# Altium schematic sheet MainBoard.SchDoc
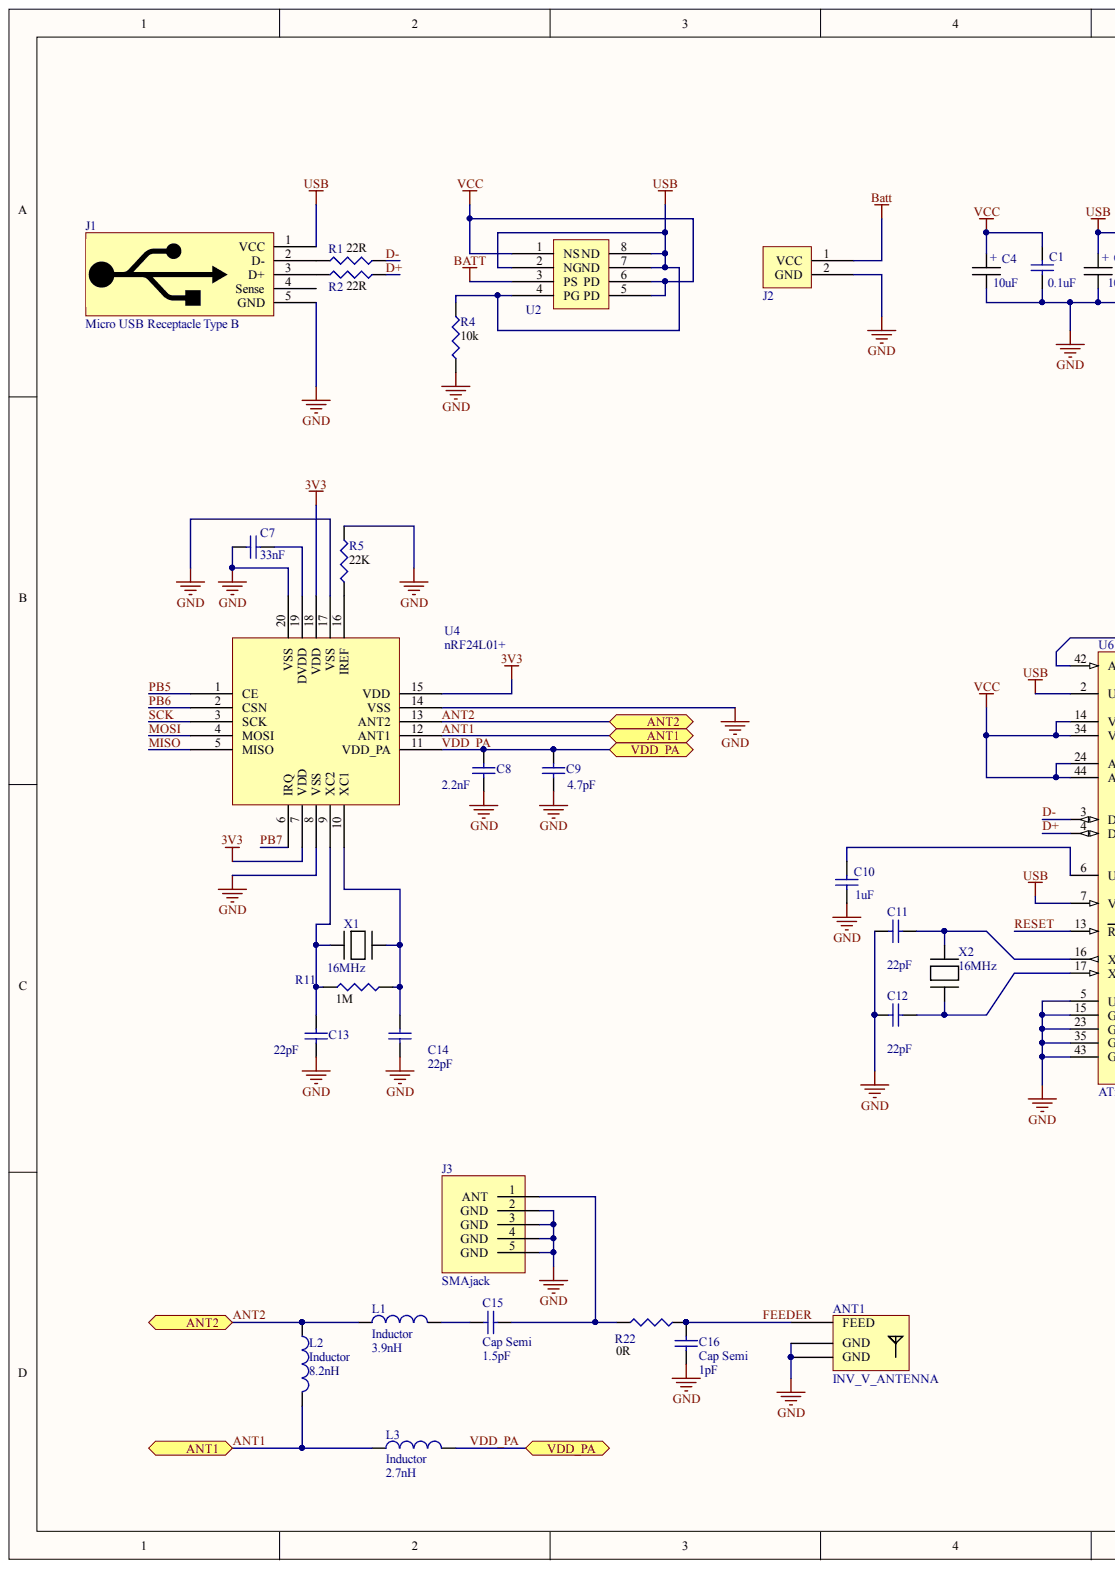
[diagram p0: MainBoard.SchDoc, left]
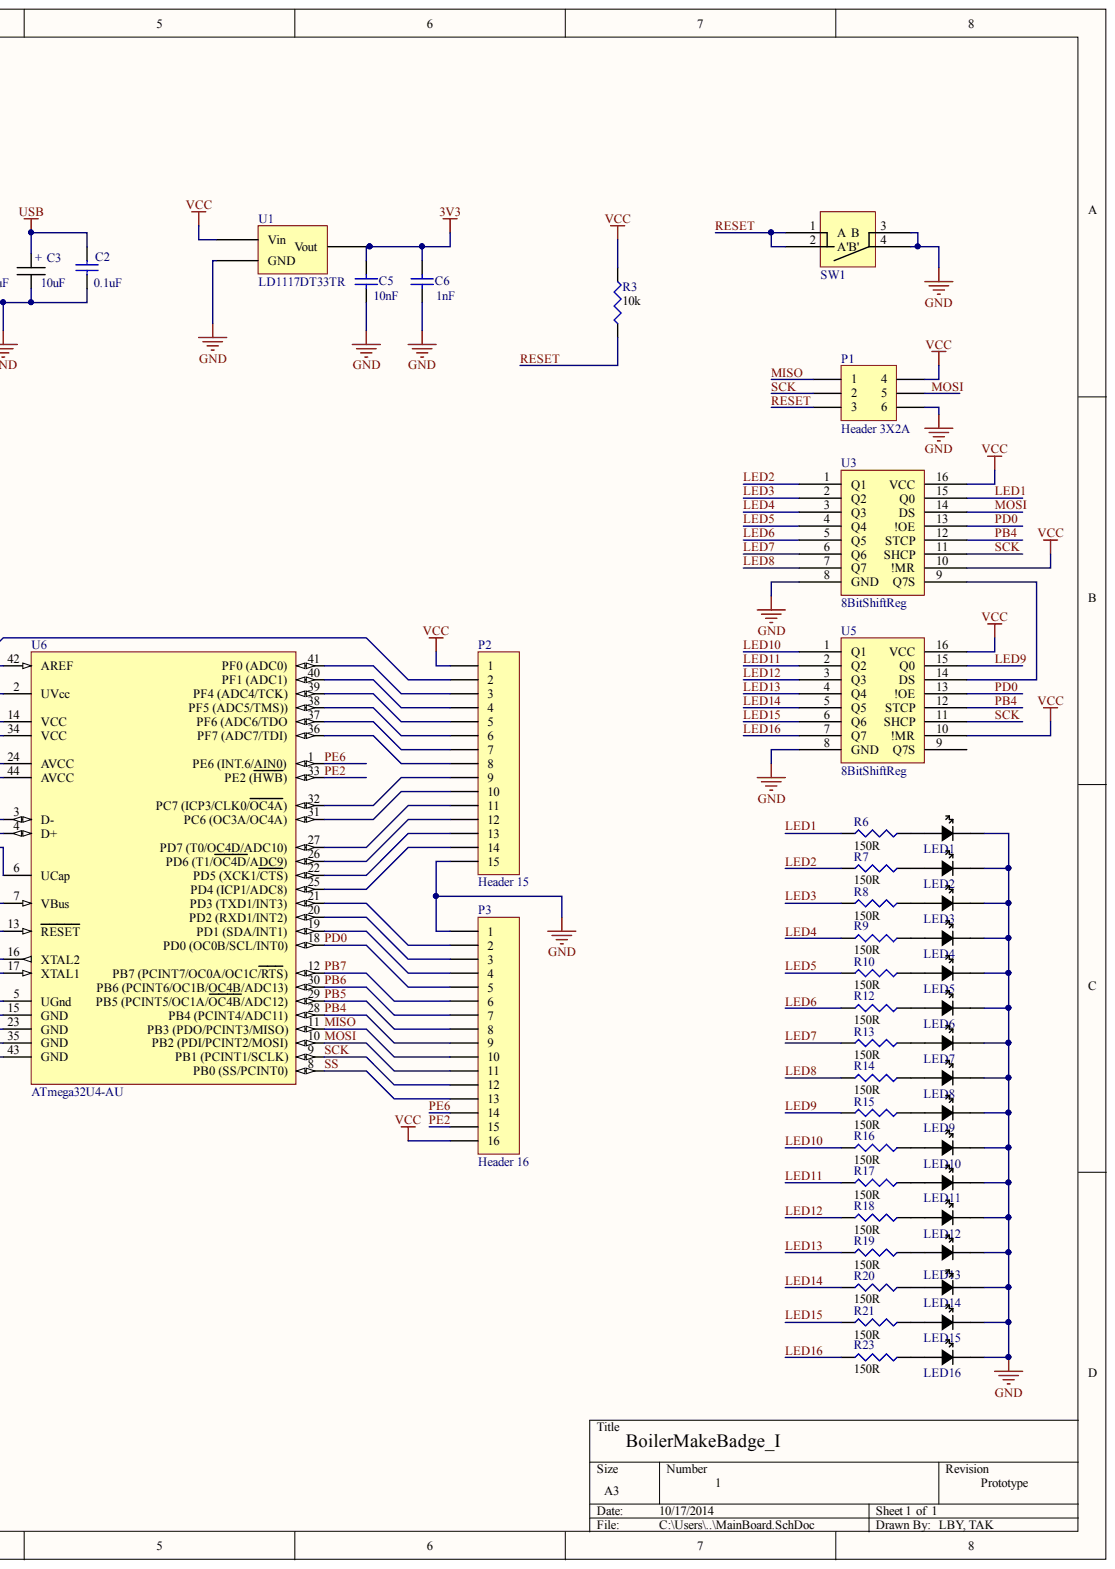
[diagram p1: MainBoard.SchDoc, right]

## Parts
ANT1  INV_V_ANTENNA  [INV_F_PCB_ANTENNA]
C1  value 0.1uF  [C1206]  (hidden comment: Cap Semi)
C2  value 0.1uF  [C1206]  (hidden comment: Cap Semi)
C3  value 10uF  [sheityCapPackage]  (hidden comment: Electrolytic Capacitor)
C4  value 10uF  [sheityCapPackage]  (hidden comment: Electrolytic Capacitor)
C5  value 10nF  [0402]  (hidden comment: Cap Semi)
C6  value 1nF  [0402]  (hidden comment: Cap Semi)
C7  value 33nF  [0402]  (hidden comment: Cap Semi)
C8  value 2.2nF  [0402]  (hidden comment: Cap Semi)
C9  value 4.7pF  [0402]  (hidden comment: Cap Semi)
C10  value 1uF  [C1206]  (hidden comment: Cap Semi)
C11  value 22pF  [C1206]  (hidden comment: Cap Semi)
C12  value 22pF  [C1206]  (hidden comment: Cap Semi)
C13  value 22pF  [C1206]  (hidden comment: Cap Semi)
C14  value 22pF  [C1206]  (hidden comment: Cap Semi)
C15  Cap Semi  value 1.5pF  [0402]
C16  Cap Semi  value 1pF  [0402]
J1  Micro USB Receptacle Type B  [USBMicroB_PCB]
J2  PowerPins  [PowerPins_PCB]  (value not drawn)
J3  SMAjack  [PCBMountVerticalJack]
L1  Inductor  value 3.9nH  [0402-A]
L2  Inductor  value 8.2nH  [0402-A]
L3  Inductor  value 2.7nH  [0402-A]
LED1  LED_Green_1206Package  [1206Package_PCB]  (value not drawn)
LED2  LED_Green_1206Package  [1206Package_PCB]  (value not drawn)
LED3  LED_Green_1206Package  [1206Package_PCB]  (value not drawn)
LED4  LED_Green_1206Package  [1206Package_PCB]  (value not drawn)
LED5  LED_Green_1206Package  [1206Package_PCB]  (value not drawn)
LED6  LED_Green_1206Package  [1206Package_PCB]  (value not drawn)
LED7  LED_Green_1206Package  [1206Package_PCB]  (value not drawn)
LED8  LED_Green_1206Package  [1206Package_PCB]  (value not drawn)
LED9  LED_Green_1206Package  [1206Package_PCB]  (value not drawn)
LED10  LED_Green_1206Package  [1206Package_PCB]  (value not drawn)
LED11  LED_Green_1206Package  [1206Package_PCB]  (value not drawn)
LED12  LED_Green_1206Package  [1206Package_PCB]  (value not drawn)
LED13  LED_Green_1206Package  [1206Package_PCB]  (value not drawn)
LED14  LED_Green_1206Package  [1206Package_PCB]  (value not drawn)
LED15  LED_Green_1206Package  [1206Package_PCB]  (value not drawn)
LED16  LED_Green_1206Package  [1206Package_PCB]  (value not drawn)
P1  Header 3X2A  [HDR2X3_CEN]
P2  Header 15  [HDR1X15]
P3  Header 16  [HDR1X16]
R1  value 22R  [1206Package_PCB]  (hidden comment: Resistor_1206Package)
R2  value 22R  [1206Package_PCB]  (hidden comment: Resistor_1206Package)
R3  value 10k  [1206Package_PCB]  (hidden comment: Resistor_1206Package)
R4  value 10k  [1206Package_PCB]  (hidden comment: Resistor_1206Package)
R5  value 22K  [0402]  (hidden comment: Resistor_1206Package)
R6  value 150R  [1206Package_PCB]  (hidden comment: Resistor_1206Package)
R7  value 150R  [1206Package_PCB]  (hidden comment: Resistor_1206Package)
R8  value 150R  [1206Package_PCB]  (hidden comment: Resistor_1206Package)
R9  value 150R  [1206Package_PCB]  (hidden comment: Resistor_1206Package)
R10  value 150R  [1206Package_PCB]  (hidden comment: Resistor_1206Package)
R11  value 1M  [0402]  (hidden comment: Resistor_1206Package)
R12  value 150R  [1206Package_PCB]  (hidden comment: Resistor_1206Package)
R13  value 150R  [1206Package_PCB]  (hidden comment: Resistor_1206Package)
R14  value 150R  [1206Package_PCB]  (hidden comment: Resistor_1206Package)
R15  value 150R  [1206Package_PCB]  (hidden comment: Resistor_1206Package)
R16  value 150R  [1206Package_PCB]  (hidden comment: Resistor_1206Package)
R17  value 150R  [1206Package_PCB]  (hidden comment: Resistor_1206Package)
R18  value 150R  [1206Package_PCB]  (hidden comment: Resistor_1206Package)
R19  value 150R  [1206Package_PCB]  (hidden comment: Resistor_1206Package)
R20  value 150R  [1206Package_PCB]  (hidden comment: Resistor_1206Package)
R21  value 150R  [1206Package_PCB]  (hidden comment: Resistor_1206Package)
R22  value 0R  [1206Package_PCB]  (hidden comment: Resistor_1206Package)
R23  value 150R  [1206Package_PCB]  (hidden comment: Resistor_1206Package)
SW1  PushButton  [TactileSwitchPCB]  (value not drawn)
U1  LD1117DT33TR  [SOT-223]
U2  DualNP-ChFET  [8-SOIC]  (value not drawn)
U3  8BitShiftReg  [16-SOIC]
U4  nRF24L01+  [20-VFQFN]
U5  8BitShiftReg  [16-SOIC]
U6  ATmega32U4-AU  [44ML_N]
X1  value 16MHz  [HC-49S]  (hidden comment: HC-49S)
X2  value 16MHz  [HC-49S]  (hidden comment: HC-49S)

## Ports
ANT1, ANT2, VDD_PA

## Nets
3V3: C5.2 C6.2 U1.2 U4.7(VDD) U4.15(VDD) U4.18(VDD)
BATT: J2.1 U2.3(PS)
GND: ANT1.1 ANT1.2 C1.1 C2.1 C3.2 C4.2 C5.1 C6.1 C7.2 C8.1 C9.1 C10.2 C11.2 C12.2 C13.1 C14.1 C16.2 J1.5(GND) J2.2 J3.2(GND) J3.3(GND) J3.4(GND) J3.5(GND) LED1.2 LED2.2 LED3.2 LED4.2 LED5.2 LED6.2 LED7.2 LED8.2 LED9.2 LED10.2 LED11.2 LED12.2 LED13.2 LED14.2 LED15.2 LED16.2 P1.6 P2.15 P3.1 R4.1 R5.2 SW1.3(B) SW1.4(B') U1.1 U3.8(GND) U4.8(VSS) U4.14(VSS) U4.17(VSS) U4.20(VSS) U5.8(GND) U6.5(UGnd) U6.15(GND) U6.23(GND) U6.35(GND) U6.43(GND)
USB: C2.2 C3.1 J1.1(VCC) R4.2 U2.2(NG) U2.4(PG) U2.7(ND) U2.8(ND) U6.2(UVcc) U6.7(VBus)
VCC: C1.2 C4.1 P1.4 P2.1 P3.16 R3.2 U1.3 U2.1(NS) U2.5(PD) U2.6(PD) U3.10(!MR) U3.16(VCC) U5.10(!MR) U5.16(VCC) U6.14(VCC) U6.24(AVCC) U6.34(VCC) U6.44(AVCC)
ANT1: L2.2 L3.1 U4.12(ANT1) ; port ANT1
ANT2: L1.1 L2.1 U4.13(ANT2) ; port ANT2
D+: R2.1 U6.4(D+)
D-: R1.1 U6.3(D-)
FEEDER: ANT1.3 C16.1 R22.2
LED1: R6.1 U3.15(Q0)
LED2: R7.1 U3.1(Q1)
LED3: R8.1 U3.2(Q2)
LED4: R9.1 U3.3(Q3)
LED5: R10.1 U3.4(Q4)
LED6: R12.1 U3.5(Q5)
LED7: R13.1 U3.6(Q6)
LED8: R14.1 U3.7(Q7)
LED9: R15.1 U5.15(Q0)
LED10: R16.1 U5.1(Q1)
LED11: R17.1 U5.2(Q2)
LED12: R18.1 U5.3(Q3)
LED13: R19.1 U5.4(Q4)
LED14: R20.1 U5.5(Q5)
LED15: R21.1 U5.6(Q6)
LED16: R23.1 U5.7(Q7)
MISO: P1.1 P3.10 U4.5(MISO) U6.11
MOSI: P1.5 P3.11 U3.14(DS) U4.4(MOSI) U6.10
PB4: P3.9 U3.12(STCP) U5.12(STCP) U6.28(PB4_(PCINT4/ADC11))
PB5: P3.8 U4.1(CE) U6.29
PB6: P3.7 U4.2(CSN) U6.30(PB6_(PCINT6/OC1B/OC4B/ADC13))
PB7: P3.6 U4.6(IRQ) U6.12
PD0: P3.5 U3.13(!OE) U5.13(!OE) U6.18(PD0_(OC0B/SCL/INT0))
PE2: P3.15 U6.33(PE2_(HWB))
PE6: P3.14 U6.1(PE6_(INT.6/AIN0))
RESET: P1.3 R3.1 SW1.1(A) SW1.2(A') U6.13(RESET)
SCK: P1.2 P3.12 U3.11(SHCP) U4.3(SCK) U5.11(SHCP) U6.9(PB1_(PCINT1/SCLK))
SS: P3.13 U6.8(PB0_(SS/PCINT0))
VDD_PA: C8.2 C9.2 L3.2 U4.11(VDD_PA) ; port VDD_PA
NetC7_1: C7.1 U4.19(DVDD)
NetC10_1: C10.1 U6.6(UCap)
NetC11_1: C11.1 U6.16(XTAL2) X2.2
NetC12_1: C12.1 U6.17(XTAL1) X2.1
NetC13_2: C13.2 R11.1 U4.9(XC2) X1.1
NetC14_2: C14.2 R11.2 U4.10(XC1) X1.2
NetC15_1: C15.1 J3.1(ANT) R22.1
NetC15_2: C15.2 L1.2
NetJ1_2: J1.2(D-) R1.2
NetJ1_3: J1.3(D+) R2.2
NetLED1_1: LED1.1 R6.2
NetLED2_1: LED2.1 R7.2
NetLED3_1: LED3.1 R8.2
NetLED4_1: LED4.1 R9.2
NetLED5_1: LED5.1 R10.2
NetLED6_1: LED6.1 R12.2
NetLED7_1: LED7.1 R13.2
NetLED8_1: LED8.1 R14.2
NetLED9_1: LED9.1 R15.2
NetLED10_1: LED10.1 R16.2
NetLED11_1: LED11.1 R17.2
NetLED12_1: LED12.1 R18.2
NetLED13_1: LED13.1 R19.2
NetLED14_1: LED14.1 R20.2
NetLED15_1: LED15.1 R21.2
NetLED16_1: LED16.1 R23.2
NetP2_2: P2.2 U6.42(AREF)
NetP2_3: P2.3 U6.41(PF0_(ADC0))
NetP2_4: P2.4 U6.40(PF1_(ADC1))
NetP2_5: P2.5 U6.39(PF4_(ADC4/TCK))
NetP2_6: P2.6 U6.38(PF5_(ADC5/TMS)))
NetP2_7: P2.7 U6.37(PF6_(ADC6/TDO)
NetP2_8: P2.8 U6.36(PF7_(ADC7/TDI))
NetP2_9: P2.9 U6.32(PC7_(ICP3/CLK0/OC4A))
NetP2_10: P2.10 U6.31(PC6_(OC3A/OC4A))
NetP2_11: P2.11 U6.27(PD7_(T0/OC4D/ADC10))
NetP2_12: P2.12 U6.26(PD6_(T1/OC4D/ADC9))
NetP2_13: P2.13 U6.22(PD5_(XCK1/CTS))
NetP2_14: P2.14 U6.25(PD4_(ICP1/ADC8))
NetP3_2: P3.2 U6.21(PD3_(TXD1/INT3))
NetP3_3: P3.3 U6.20(PD2_(RXD1/INT2))
NetP3_4: P3.4 U6.19(PD1_(SDA/INT1))
NetR5_1: R5.1 U4.16(IREF)
NetU3_9: U3.9(Q7S) U5.14(DS)

Not connected: J1 4(Sense); U5 9(Q7S)

## Library data of the parts
C1 C2 C5-C16 = Cap Semi: Capacitor (Semiconductor SIM Model); Component Kind: Standard; LatestRevisionDate: 29-May-2009; LatestRevisionNote: IPC-7351 Footprint Added.; Library Name: Miscellaneous Devices.IntLib; PackageDescription: Chip Capacitor; PackageReference: C1206; Published: 8-Jun-2000; Signal Integrity: Cap Semi; Simulation: CAP; Publisher: Altium Limited
C3 C4 = Electrolytic Capacitor: asdf; Component Kind: Standard; Library Name: BoilermakeBadge_ComponentsLibrary.IntLib
J1 = USBMicroB: Component Kind: Standard; Library Name: BoilermakeBadge_ComponentsLibrary.IntLib
J3 = SMAconnector: Component Kind: Standard; Library Name: BoilermakeBadge_ComponentsLibrary.IntLib
L1-L3 = Inductor: Code_IEC: 1005; Code_JEITA: 0402; Component Kind: Standard; Description: Inductor; LatestRevisionDate: 29-May-2009; LatestRevisionNote: IPC-7351 Footprint Added.; Library Name: Miscellaneous Devices.IntLib; PackageDescription: Chip Inductor; PackageReference: 0402-A; Published: 8-Jun-2000; Signal Integrity: Inductor; Simulation: INDUCTOR; Publisher: Altium Limited
LED1-LED16 = LED_Green_1206Package: Green LED; Component Kind: Standard; Library Name: BoilermakeBadge_ComponentsLibrary.IntLib
P1 = Header 3X2A: Header, 3-Pin, Dual row; Component Kind: Standard; LatestRevisionDate: 17-Jul-2002; LatestRevisionNote: Re-released for DXP Platform.; Library Name: Miscellaneous Connectors.IntLib; Signal Integrity: Connector; Publisher: Altium Limited
P2 = Header 15: Header, 15-Pin; Component Kind: Standard; LatestRevisionDate: 17-Jul-2002; LatestRevisionNote: Re-released for DXP Platform.; Library Name: Miscellaneous Connectors.IntLib; Signal Integrity: Connector; Publisher: Altium Limited
P3 = Header 16: Header, 16-Pin; Component Kind: Standard; LatestRevisionDate: 17-Jul-2002; LatestRevisionNote: Re-released for DXP Platform.; Library Name: Miscellaneous Connectors.IntLib; Signal Integrity: Connector; Publisher: Altium Limited
R1-R23 = Resistor_1206Package: Resistor 1206; Component Kind: Standard; Library Name: BoilermakeBadge_ComponentsLibrary.IntLib
SW1 = TactileButton: Normally open push button.; Component Kind: Standard; Library Name: BoilermakeBadge_ComponentsLibrary.IntLib
U2 = Dual N & P-Channel MOSFET: Component Kind: Standard; Library Name: BoilermakeBadge_ComponentsLibrary.IntLib
U3 U5 = 8BitShiftRegister: Component Kind: Standard; Library Name: BoilermakeBadge_ComponentsLibrary.IntLib
U4 = nRF24L01+: 2.4GHz RF Transceiver; Component Kind: Standard; Library Name: BoilermakeBadge_ComponentsLibrary.IntLib
U6 = ATmega32U4-AU: 8-bit AVR Microcontroller, 2.7-5.5V, 32KB Flash, 2.5KB EEPROM, 1KB SRAM, USB Controller, 44-pin TQFP, Industrial Grade (-40°C to 85°C); Component Kind: Standard; ComponentLink1Description: Manufacturer Link; ComponentLink1URL: http://www.atmel.com/products/avr/default.asp?; ComponentLink2Description: ATmega16U4/32U4 Datasheet; ComponentLink2URL: http://www.atmel.com/dyn/resources/prod_documents/doc7766.pdf; ComponentLink3Description: ATmega16U4 ATmega32U4 Summary; ComponentLink3URL: http://www.atmel.com/dyn/resources/prod_documents/7766S.pdf; ComponentLink4Description: Atmel.com Home; ComponentLink4URL: http://www.atmel.com; DatasheetVersion: revD, Jan-2009; Library Name: Atmel Microcontroller 8-Bit megaAVR.IntLib; PackageDescription: 44-Pin Plastic Thin Quad Flat Pack, 10 x 10 x 1.0 mm Body, 0.8 mm Pitch (TQFP); PackageReference: 44ML; PackageVersion: rev.G, Jul-2007; Published: 1-Apr-2010; Supplier 1: Digi-Key; Supplier 2: Farnell; Supplier 3: Mouser; Supplier Part Number 1: ATMEGA32U4-AU-ND; Supplier Part Number 2: 1748525; Supplier Part Number 3: 556-ATMEGA32U4-AU; Publisher: Altium Limited
ANT1 = INV_V_ANTENNA: Component Kind: Standard; Library Name: BoilermakeBadge_ComponentsLibrary.IntLib
J2 = PowerPins: Component Kind: Standard; Library Name: BoilermakeBadge_ComponentsLibrary.IntLib
U1 = LD1117DT33TR: Component Kind: Standard; Library Name: BoilermakeBadge_ComponentsLibrary.IntLib
X1 X2 = HC-49S: Component Kind: Standard; Library Name: BoilermakeBadge_ComponentsLibrary.IntLib
